# Altium schematic sheet LEDs_BUTTON.SchDoc(LEDs_BUTTON)
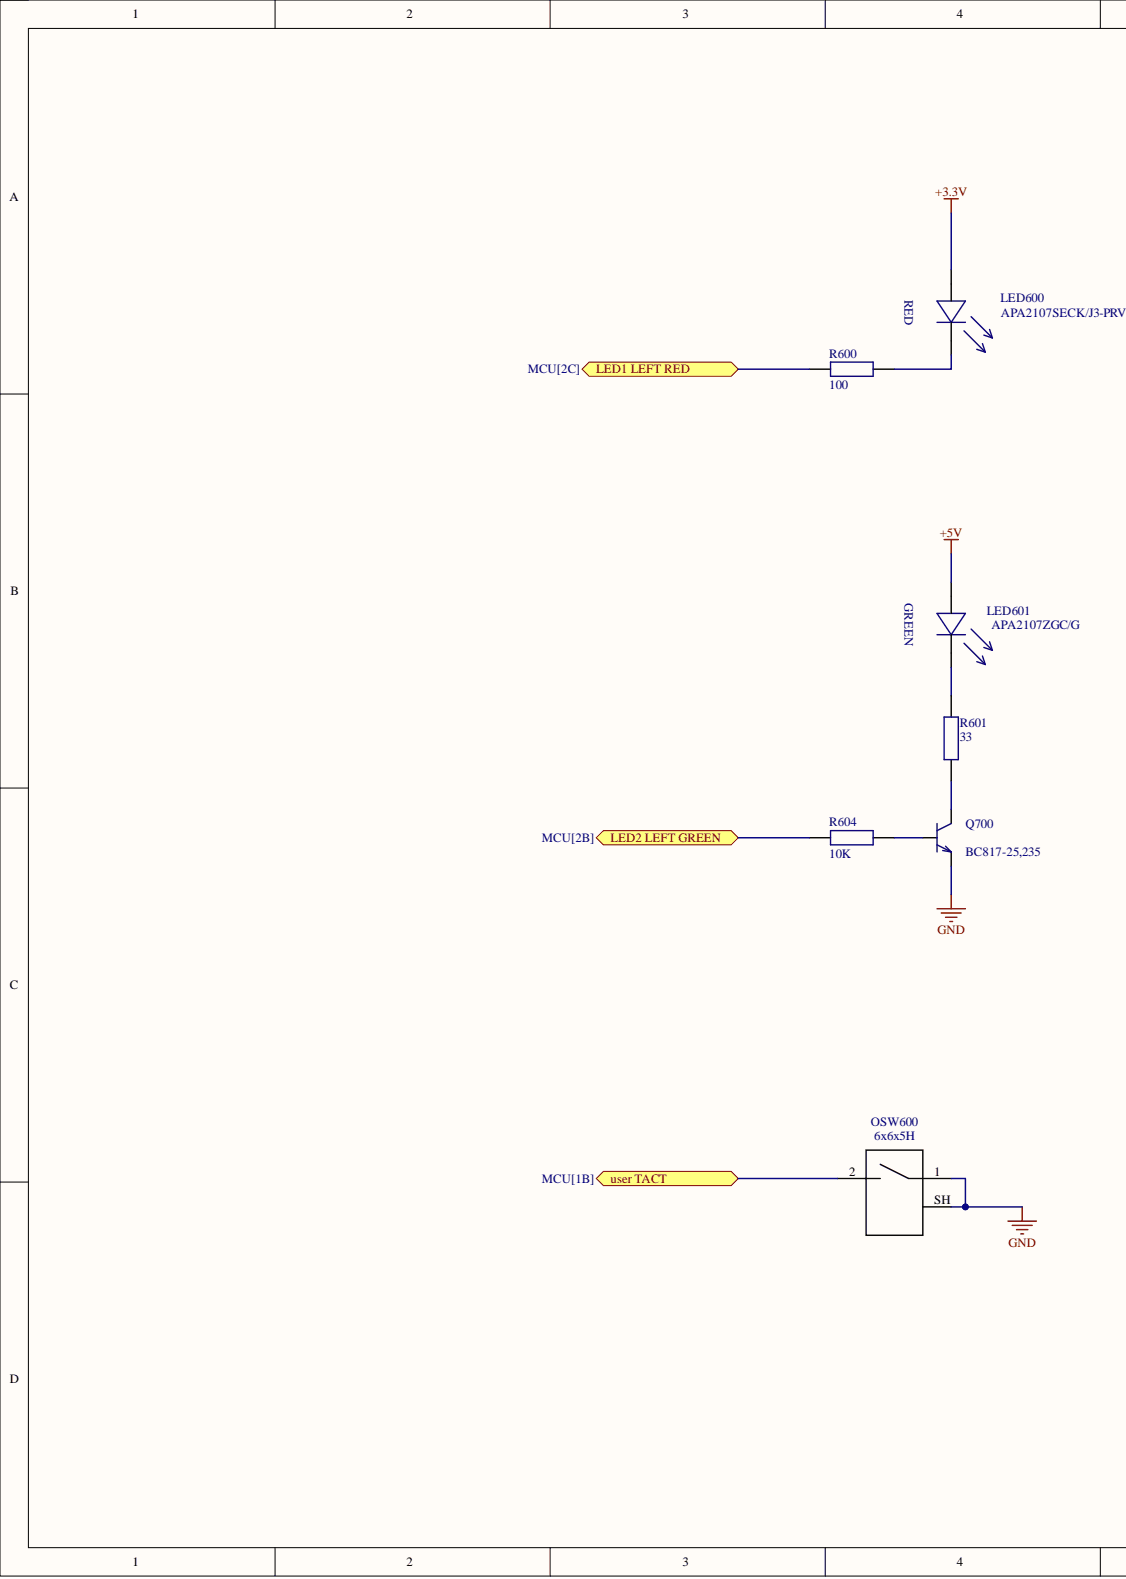
[diagram p0: LEDs_BUTTON.SchDoc, left]
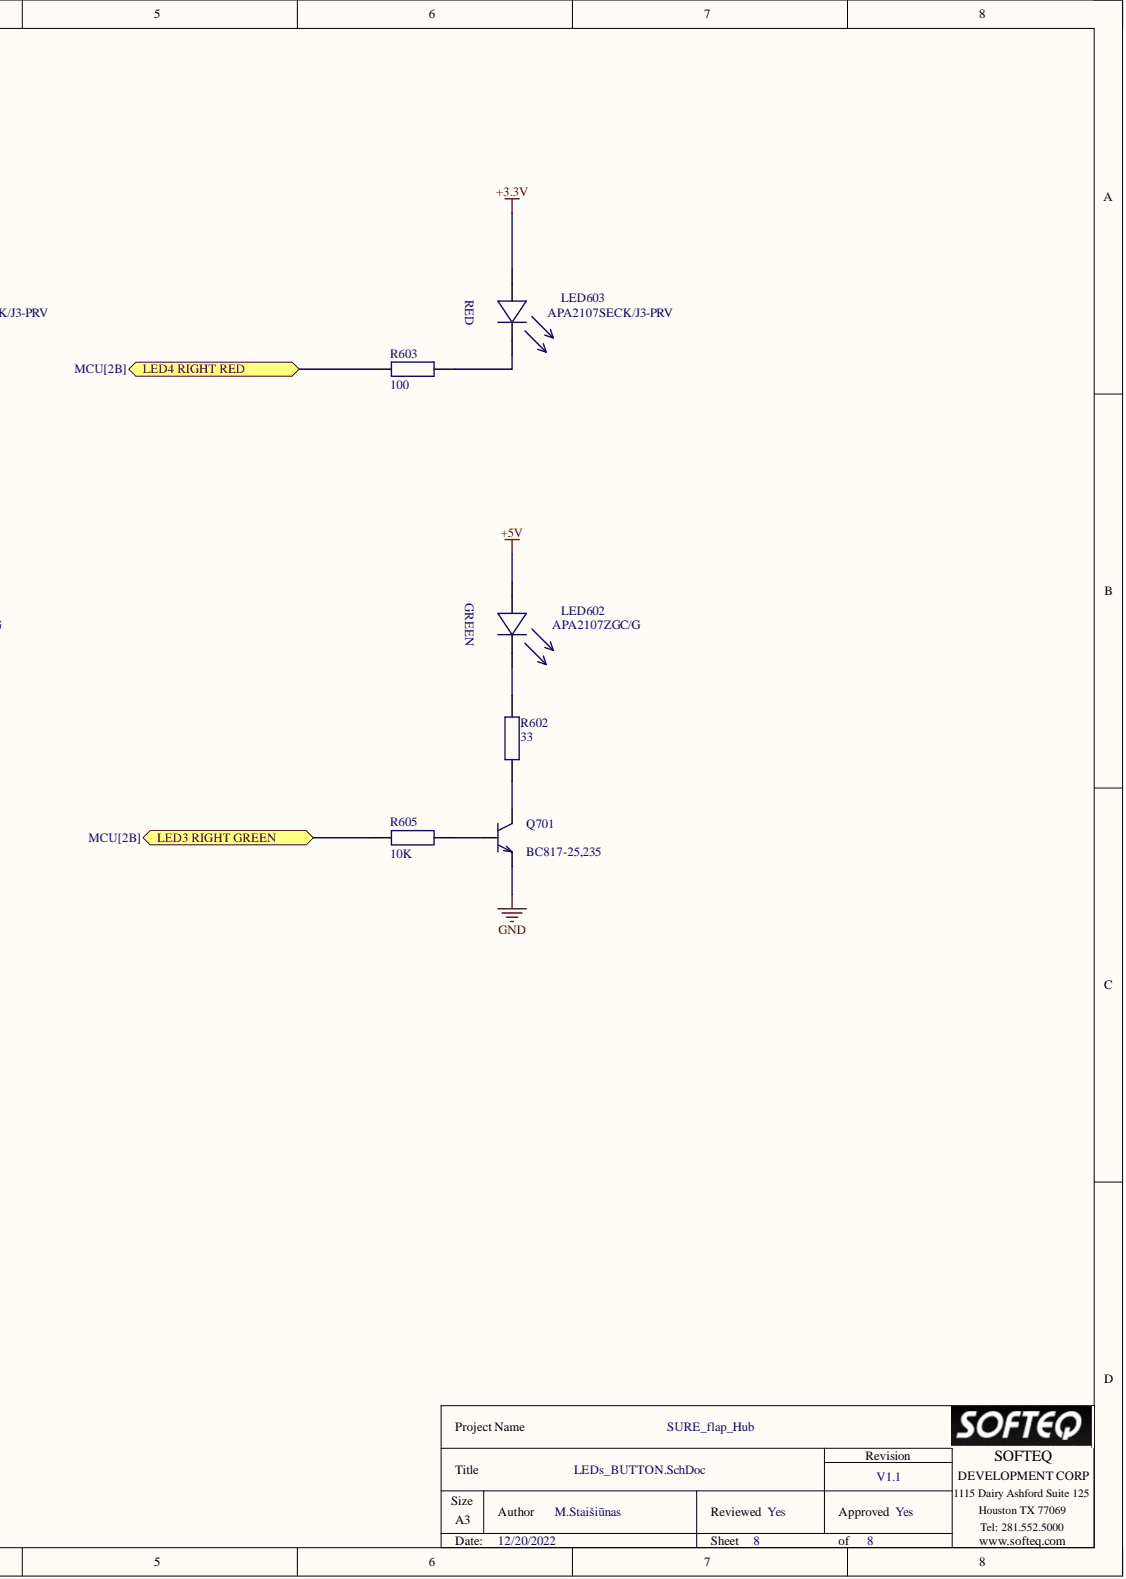
[diagram p1: LEDs_BUTTON.SchDoc, right]

## Parts
LED600  APA2107SECK/J3-PRV  [Kingbright_APA2107_ALT]
LED601  APA2107ZGC/G  [Kingbright_APA2107_ALT]
LED602  APA2107ZGC/G  [Kingbright_APA2107_ALT]
LED603  APA2107SECK/J3-PRV  [Kingbright_APA2107_ALT]
OSW600  6x6x5H  [DB_6x6x5H_ALT]
Q700  BC817-25,235  [SOT-23-3]
Q701  BC817-25,235  [SOT-23-3]
R600  value 100  [R_0402]  (hidden comment: RC0402FR-07100RL)
R601  value 33  [R_0805]  (hidden comment: RC0805FR-1033RL)
R602  value 33  [R_0805]  (hidden comment: RC0805FR-1033RL)
R603  value 100  [R_0402]  (hidden comment: RC0402FR-07100RL)
R604  value 10K  [R_0402]  (hidden comment: RC0402FR-0710KL)
R605  value 10K  [R_0402]  (hidden comment: RC0402FR-0710KL)

## Ports
LED1 LEFT RED, LED2 LEFT GREEN, LED3 RIGHT GREEN, LED4 RIGHT RED, user TACT

## Nets
+3.3V: LED600.A LED603.A
+5V: LED601.A LED602.A
GND: OSW600.1 OSW600.SH Q700.2 Q701.2
LED1 LEFT RED: R600.1 ; port LED1 LEFT RED
LED2 LEFT GREEN: R604.2 ; port LED2 LEFT GREEN
LED3 RIGHT GREEN: R605.2 ; port LED3 RIGHT GREEN
LED4 RIGHT RED: R603.1 ; port LED4 RIGHT RED
user TACT: OSW600.2 ; port user TACT
NetLED600_C: LED600.C R600.2
NetLED601_C: LED601.C R601.2
NetLED602_C: LED602.C R602.2
NetLED603_C: LED603.C R603.2
NetQ700_1: Q700.1 R604.1
NetQ700_3: Q700.3 R601.1
NetQ701_1: Q701.1 R605.1
NetQ701_3: Q701.3 R602.1

## Library data of the parts
LED600 LED603 = APA2107SECK/J3-PRV: Diode, LED, RED, 2.4V, 20mA, -40/+85, 0903; Manufacturer: Kingbright; Database Table Name: Diode_Transistor; Component Kind: Standard; ComponentHeight: 0.6mm; Library Name: Library.dblib; Mounted: Yes; PartNumber: APA2107SECK/J3-PRV; SMD: Yes; Author: DZ
LED601 LED602 = APA2107ZGC/G: Diode, LED, GREEN, 2.4V, 20mA, -40/+85, 1212; Manufacturer: Kingbright; Database Table Name: Diode_Transistor; Component Kind: Standard; ComponentHeight: 0.7mm; Library Name: Library.dblib; Mounted: Yes; PartNumber: APA2107ZGC/G; SMD: Yes; Author: DZ
OSW600 = 6x6x5H: Push Button, Top Actuated, 12VDC, 0.5A, -25/+85, RA, TH; Manufacturer: Dongguan Baoda; Database Table Name: Other; Component Kind: Standard; ComponentHeight: 7mm; Library Name: Library.dblib; Mounted: Yes; PartNumber: 6x6x5H; SMD: No; Author: DZ
Q700 Q701 = BC817-25,235: Transistor, Bipolar, NPN, 45V, 500mA, -65/+150, SOT-23; Manufacturer: Nexperia; Database Table Name: Diode_Transistor; Component Kind: Standard; ComponentHeight: 1.1mm; Library Name: Library.dblib; Mounted: Yes; PartNumber: BC817-25,235; SMD: Yes; Author: DZ
R600 R603 = RC0402FR-07100RL: Resistor, 100, 1%, 0.063W, -55/+155, 0402; Manufacturer: Yageo; Database Table Name: Resistors; Component Kind: Standard; ComponentHeight: 0.4mm; Library Name: Library.dblib; Mounted: Yes; PartNumber: RC0402FR-07100RL; SMD: Yes; Author: KAI
R601 R602 = RC0805FR-1033RL: Resistor, 33Ohm, 1%, 0.25W, -55/+155, 0805; Manufacturer: Yageo; Database Table Name: Resistors; Component Kind: Standard; ComponentHeight: 0.6mm; Library Name: Library.dblib; Mounted: Yes; PartNumber: RC0805FR-1033RL; SMD: Yes; Author: DZ
R604 R605 = RC0402FR-0710KL: Resistor, 10K, 1%, 0.063W, -55/+155, 0402; Manufacturer: Yageo; Database Table Name: Resistors; Component Kind: Standard; ComponentHeight: 0.4mm; Library Name: Library.dblib; Mounted: Yes; PartNumber: RC0402FR-0710KL; SMD: Yes; Author: KAI
